# Altium schematic sheet 03_Power_a_Connector.SchDoc(03_Power_a_Connector)
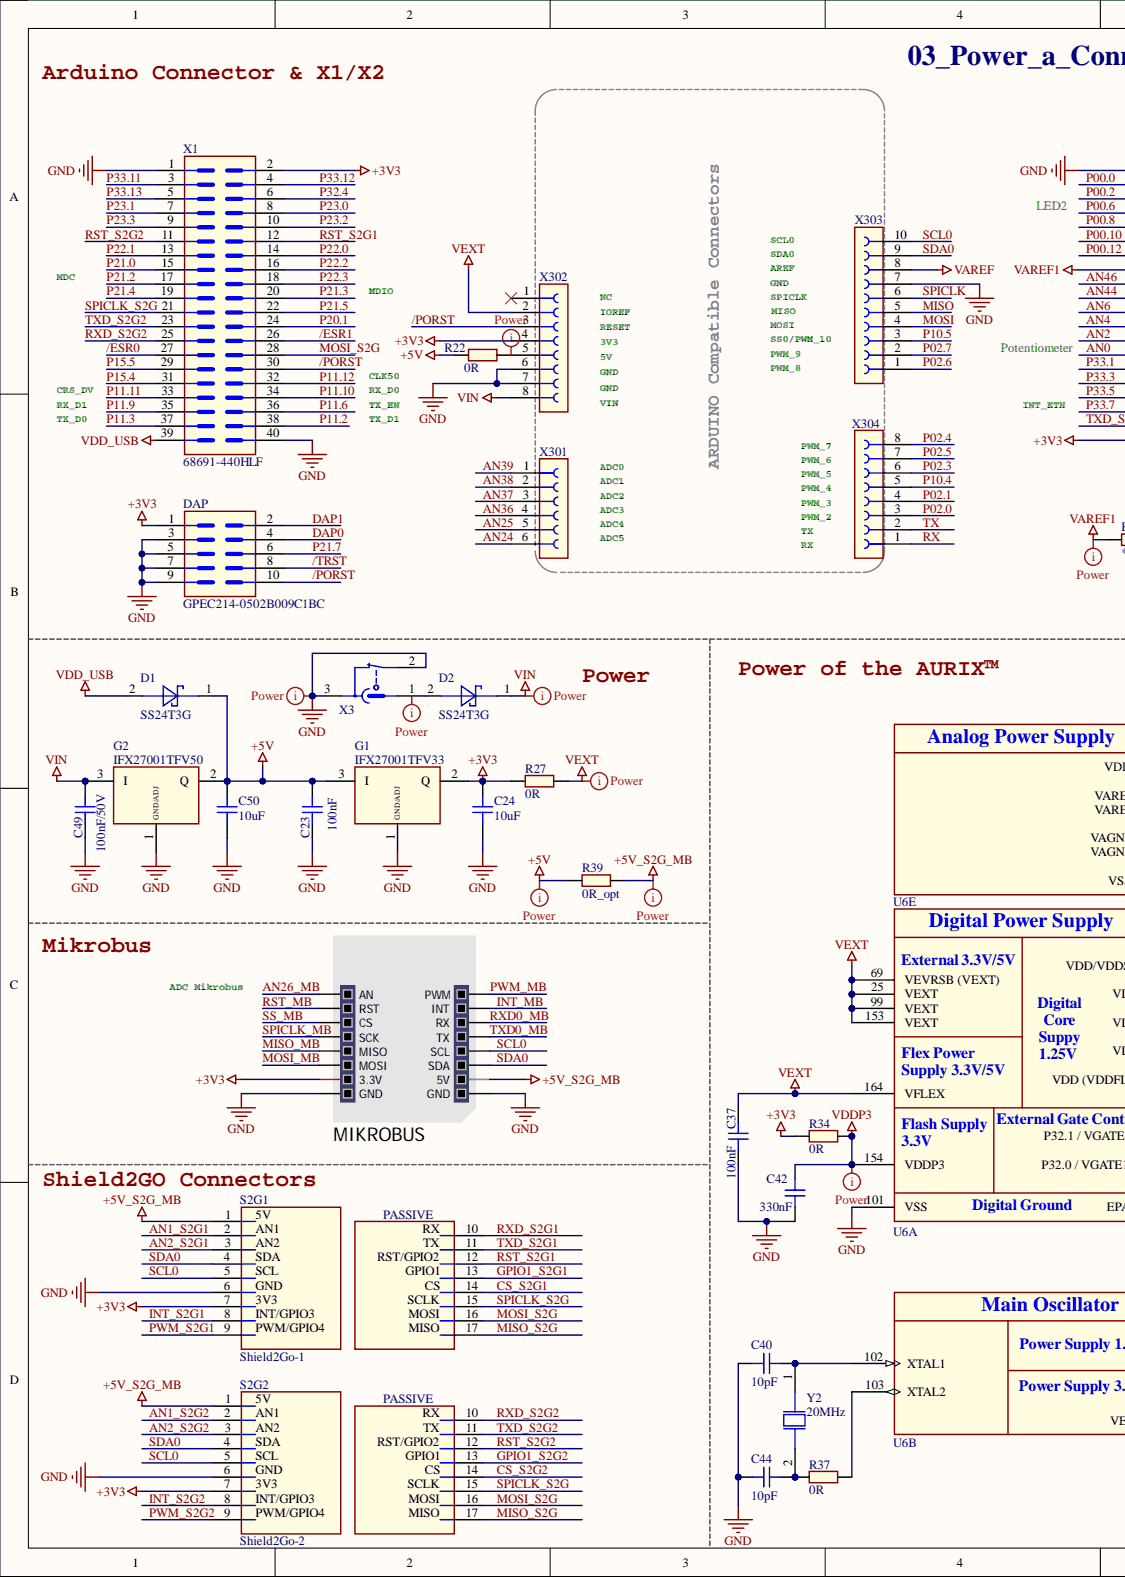
[diagram p0: 03_Power_a_Connector.SchDoc, left]
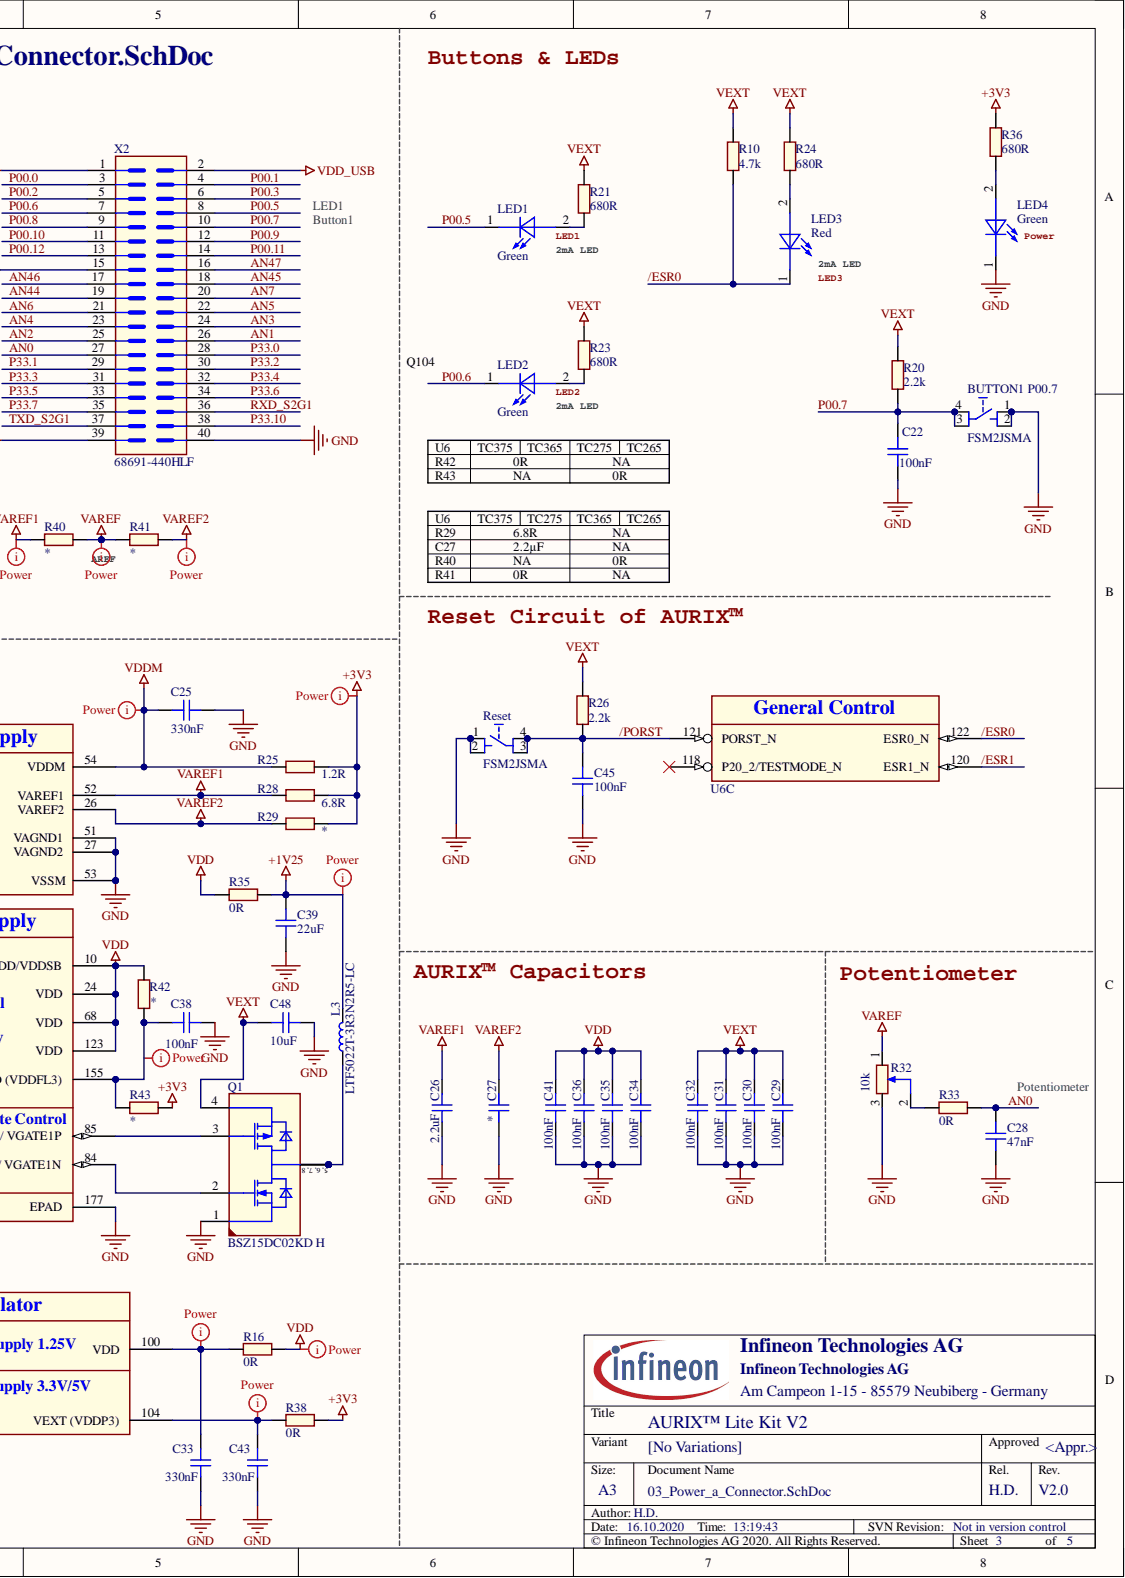
[diagram p1: 03_Power_a_Connector.SchDoc, right]

## Parts
BUTTON1_P00.7  FSM2JSMA  [FSM2JSMA]
C22  100nF  [CAPC1005X55N-1]
C23  100nF  [CAPC1005X55N-1]
C24  10uF  [CAPC1005X70N]
C25  330nF  [CAPC1005X55N-1]
C26  2.2uF  [CAPC1608X90N]
C27  (no value)  [CAPC1608X90N]
C28  47nF  [CAPC1608X90N-4]
C29  100nF  [CAPC1005X55N-1]
C30  100nF  [CAPC1005X55N-1]
C31  100nF  [CAPC1005X55N-1]
C32  100nF  [CAPC1005X55N-1]
C33  330nF  [CAPC1005X55N-1]
C34  100nF  [CAPC1005X55N-1]
C35  100nF  [CAPC1005X55N-1]
C36  100nF  [CAPC1005X55N-1]
C37  100nF  [CAPC1005X55N-1]
C38  100nF  [CAPC1005X55N-1]
C39  22uF  [CAPC3225X280N-3]
C40  10pF  [CAPC1005X55N-0]
C41  100nF  [CAPC1005X55N-1]
C42  330nF  [CAPC1005X55N-1]
C43  330nF  [CAPC1005X55N-1]
C44  10pF  [CAPC1005X55N-0]
C45  100nF  [CAPC1005X55N-1]
C48  10uF  [CAPC3225X280N-5]
C49  100nF/50V  [CAPC1608X90N-4]
C50  10uF  [CAPC1005X70N]
D1  SS24T3G  [DIOM5436X247N]
D2  SS24T3G  [DIOM5436X247N]
DAP  GPEC214-0502B009C1BC  [CON-M-SMD-GPEC214-0502B009C1BC]
G1  IFX27001TFV33  [INF-PG-TO252-3-11_N]
G2  IFX27001TFV50  [INF-PG-TO252-3-11_N]
L3  LTF5022T-3R3N2R5-LC  [IND-LTF5022T]
LED1  Green  Type: LED  [LED-SMD-LG L29K-XXXX-24]
LED2  Green  Type: LED  [LED-SMD-LG L29K-XXXX-24]
LED3  Red  Type: LED  [LEDSC160X80X80-2N]
LED4  Green  [LED-SMD-LG L29K-XXXX-24]
MIKROBUS  MIKROBUS HOST CONN.  [MIKROBUS HOST CONN.]  (value not drawn)
Q1  BSZ15DC02KD H  [INF-PG-TSDSON-8-31]
R10  4.7k  [RESC1005X40N-1]
R16  0R  [RESC1609X50N]
R20  2.2k  [RESC1005X40N]
R21  680R  [RESC1005X40N]
R22  0R  [RESC1609X50N]
R23  680R  [RESC1005X40N]
R24  680R  [RESC1005X40N]
R25  1.2R  [RESC1609X50N]
R26  2.2k  [RESC1005X40N]
R27  0R  [RESC1609X50N]
R28  6.8R  [RESC1609X50N]
R29  (no value)  [RESC1609X50N]
R32  10k  [POT_23A]
R33  0R  [RESC1609X50N]
R34  0R  [RESC1609X50N]
R35  0R  [RESC1609X50N]
R36  680R  [RESC1005X40N]
R37  0R  [RESC1609X50N]
R38  0R  [RESC1609X50N]
R39  0R_opt  [RESC1609X50N]
R40  (no value)  [RESC1609X50N]
R41  (no value)  [RESC1609X50N]
R42  (no value)  [RESC1609X50N]
R43  (no value)  [RESC1609X50N]
Reset  FSM2JSMA  [FSM2JSMA]
S2G1  Shield2Go-1  [Shield2Go_S2G_1P]  (no BOM)
S2G2  Shield2Go-2  [Shield2Go_S2G_1P]  (no BOM)
U6  TC375  [QFP50P2600X2600X160-177N]  (value not drawn)  (parts A,B,C,E)
X1  68691-440HLF  [CON-M-THT-68691-440HLF]
X2  68691-440HLF  [CON-M-THT-68691-440HLF]
X3  con_pwr13_ncc32_3p-A  [CON-THT-JACK-FC681465P]  (value not drawn)
X301  Socket 6  [CON-F-THT-SSQ-106-03-G-S]  (value not drawn)
X302  Socket 8  [CON-F-THT-PPTC081LFBN-RC]  (value not drawn)
X303  PPTC101LFBN-RC  [CON-F-THT-SSQ-110-03-F-S]  (value not drawn)
X304  Socket 8  [CON-F-THT-PPTC081LFBN-RC]  (value not drawn)
Y2  20MHz  [XTAL-SMD-NX8045GB]

## Nets
+1V25: C39.2 L3.2 R35.1
+3V3: C24.2 DAP.1 G1.2 MIKROBUS.7(3.3V) R25.2 R27.1 R28.2 R29.2 R34.2 R36.2 R38.2 R43.2 S2G1.7 S2G2.7(3V3) X1.2 X2.39 X302.4
+5V: C23.1 C50.2 D1.1 G1.3 G2.2 R22.1 R39.1
+5V_S2G_MB: MIKROBUS.10(5V) R39.2 S2G1.1 S2G2.1(5V)
GND: BUTTON1_P00.7.1 BUTTON1_P00.7.2 C22.1 C23.2 C24.1 C25.1 C26.1 C27.1 C28.1 C29.1 C30.1 C31.1 C32.1 C33.1 C34.1 C35.1 C36.2 C37.2 C38.2 C39.1 C40.1 C41.2 C42.1 C43.1 C44.1 C45.1 C48.2 C49.2 C50.1 DAP.3 DAP.5 DAP.7 DAP.9 G1.1 G2.1 LED4.1 MIKROBUS.8(GND) MIKROBUS.9(GND) Q1.1 R32.3 Reset.1 Reset.2 S2G1.6 S2G2.6(GND) U6.27(VAGND2) U6.51(VAGND1) U6.53(VSSM) U6.101(VSS) U6.177(EPAD) X1.1 X1.40 X2.1 X2.40 X3.2 X3.3 X302.6 X302.7 X303.7
VAREF: R32.1 R40.1 R41.1 X303.8
VAREF1: C26.2 R28.1 R40.2 U6.52(VAREF1) X2.15
VAREF2: C27.2 R29.1 R41.2 U6.26(VAREF2)
VDD: C34.2 C35.2 C36.1 C41.1 R16.2 R35.2 R42.2 U6.10(VDD/VDDSB) U6.24(VDD) U6.68(VDD) U6.123(VDD)
VDD_USB: D1.2 X1.39 X2.2
VDDM: C25.2 R25.1 U6.54(VDDM)
VDDP3: C42.2 R34.1 U6.154(VDDP3)
VEXT: C29.2 C30.2 C31.2 C32.2 C37.1 C48.1 Q1.4 R10.2 R20.2 R21.2 R23.2 R24.1 R26.2 R27.2 U6.25(VEXT) U6.69(VEVRSB_(VEXT)) U6.99(VEXT) U6.153(VEXT) U6.164(VFLEX) X302.2
VIN: C49.1 D2.1 G2.3 X302.8
/ESR0: LED3.1 R10.1 U6.122(ESR0_N) X1.27
/ESR1: U6.120(ESR1_N) X1.26
/PORST: C45.2 DAP.10 R26.1 Reset.3 Reset.4 U6.121(PORST_N) X1.30 X302.3
/TRST: DAP.8
AN0: C28.2 R33.2 X2.27
AN1: X2.26
AN1_S2G1: S2G1.2
AN1_S2G2: S2G2.2(AN1)
AN2: X2.25
AN2_S2G1: S2G1.3(AN2)
AN2_S2G2: S2G2.3
AN3: X2.24
AN4: X2.23
AN5: X2.22
AN6: X2.21
AN7: X2.20
AN24: X301.6
AN25: X301.5
AN26_MB: MIKROBUS.1(AN)
AN36: X301.4
AN37: X301.3
AN38: X301.2
AN39: X301.1
AN44: X2.19
AN45: X2.18
AN46: X2.17
AN47: X2.16
CS_S2G1: S2G1.14
CS_S2G2: S2G2.14(CS)
DAP0: DAP.4
DAP1: DAP.2
GPIO1_S2G1: S2G1.13(GPIO1)
GPIO1_S2G2: S2G2.13
INT_MB: MIKROBUS.15(INT)
INT_S2G1: S2G1.8
INT_S2G2: S2G2.8
MISO: X303.5
MISO_MB: MIKROBUS.5(MISO)
MISO_S2G: S2G1.17(MISO) S2G2.17
MOSI: X303.4
MOSI_MB: MIKROBUS.6(MOSI)
MOSI_S2G: S2G1.16 S2G2.16 X1.28
P00.0: X2.3
P00.1: X2.4 X2.11  [also labelled P00.10]
P00.2: X2.5
P00.3: X2.6
P00.5: LED1.1 X2.8
P00.6: LED2.1 X2.7
P00.7: BUTTON1_P00.7.3 BUTTON1_P00.7.4 C22.2 R20.1 X2.10
P00.8: X2.9
P00.9: X2.12
P00.11: X2.14
P00.12: X2.13
P02.0: X304.3
P02.1: X304.4
P02.3: X304.6
P02.4: X304.8
P02.5: X304.7
P02.6: X303.1
P02.7: X303.2
P10.4: X304.5
P10.5: X303.3
P11.2: X1.38
P11.3: X1.37
P11.6: X1.36
P11.9: X1.35
P11.10: X1.34
P11.11: X1.33
P11.12: X1.32
P15.4: X1.31
P15.5: X1.29
P20.1: X1.24
P21.0: X1.15
P21.2: X1.17
P21.3: X1.20
P21.4: X1.19
P21.5: X1.22
P21.7: DAP.6
P22.0: X1.14
P22.1: X1.13
P22.2: X1.16
P22.3: X1.18
P23.0: X1.8
P23.1: X1.7
P23.2: X1.10
P23.3: X1.9
P32.4: X1.6
P33.0: X2.28
P33.1: X2.29 X2.38  [also labelled P33.10]
P33.2: X2.30
P33.3: X2.31
P33.4: X2.32
P33.5: X2.33
P33.6: X2.34
P33.7: X2.35
P33.11: X1.3
P33.12: X1.4
P33.13: X1.5
PWM_MB: MIKROBUS.16(PWM)
PWM_S2G1: S2G1.9(PWM/GPIO4)
PWM_S2G2: S2G2.9
RST_MB: MIKROBUS.2(RST)
RST_S2G1: S2G1.12(RST/GPIO2) X1.12
RST_S2G2: S2G2.12 X1.11
RX: X304.1
RXD0_MB: MIKROBUS.14(RX)
RXD_S2G1: S2G1.10 X2.36
RXD_S2G2: S2G2.10(RX) X1.25
SCL0: MIKROBUS.12(SCL) S2G1.5(SCL) S2G2.5 X303.10
SDA0: MIKROBUS.11(SDA) S2G1.4(SDA) S2G2.4 X303.9
SPICLK: X303.6
SPICLK_MB: MIKROBUS.4(SCK)
SPICLK_S2G: S2G1.15 S2G2.15(SCLK) X1.21
SS_MB: MIKROBUS.3(CS)
TX: X304.2
TXD0_MB: MIKROBUS.13(TX)
TXD_S2G1: S2G1.11(TX) X2.37
TXD_S2G2: S2G2.11 X1.23
NetC33_2: C33.2 R16.1 U6.100(VDD)
NetC38_1: C38.1 R42.1 R43.1 U6.155(VDD_(VDDFL3))
NetC40_2: C40.2 U6.102(XTAL1) Y2.1
NetC43_2: C43.2 R38.1 U6.104(VEXT_(VDDP3))
NetC44_2: C44.2 R37.1 Y2.2
NetD2_2: D2.2 X3.1
NetL3_1: L3.1 Q1.5 Q1.6 Q1.7 Q1.8
NetLED1_2: LED1.2 R21.1
NetLED2_2: LED2.2 R23.1
NetLED3_2: LED3.2 R24.2
NetLED4_2: LED4.2 R36.1
NetQ1_2: Q1.2 U6.84(P32.0_/_VGATE1N)
NetQ1_3: Q1.3 U6.85(P32.1_/_VGATE1P)
NetR22_2: R22.2 X302.5
NetR32_2: R32.2 R33.1
NetR37_2: R37.2 U6.103(XTAL2)

Not connected: U6 118(P20_2/TESTMODE_N); X302 1

## Library data of the parts
BUTTON1_P00.7 Reset = 376475: Micro Miniature Pushbutton Switch; Legacy PartNumber: SW0000003; Manufacturer: Tyco Electronics; Manufacturer Component Status: Active; Automotive Qualified: No; Legacy DeviceID: TEC_FSM2JSMA; Second Source allowed: Yes; Style: Not Applicable; Version Number: V01; Voltage DC (max): na; Article Number: 376475; Component Kind: Standard; ComponentLink1Description: Vendor WebSite; ComponentLink1URL: http://www.tycoelectronics.com/; ComponentLink2Description: Datasheet; ComponentLink2URL: http://webnetprod.muc.infineon.com/pcbportal_prod/WorkFlows/AltiumComponent/StandardDocumentation?Versionid=376476; Manufacturer Order Number: FSM2JSMA; Package Description: Switch,Push button ,4.5mm Pitch,Vertical, 6mm L X 6mm W X 5.4mm H body; Part Type: Electro Mechanic; PLMStatus: Active; RoHS Compliant: Y; Supplier 1: Farnell; Supplier 2: DigiKey; Supplier 3: Mouser; Supplier Part Number 1: 3801287; Supplier Part Number 3: 506-FSM2JSMA; Temperature max: 85; Temperature min: -35; Temperature Range: Military; Value: FSM2JSMA; Library Name: AURIX_Lite_Kit_V2.0.SCHLIB
C22 C23 C29-C32 C34-C38 C41 C45 = 308680: Multilayer Ceramic Chip Capacitor; Manufacturer: TDK Corporation; Manufacturer Component Status: Active; Manufacturer Order Number: CGA2B1X7R1C104K050BC; Multiple Parts: No; Alternate View: Yes; Automotive Qualified: AEC Q200; Combined Parameters: TC:X7R (EIA);Tol.:-10%/+10%;Vdc:16V; Legacy DeviceID: CAP01010669; Package Imperial: 0402; Package Metric: 1005; QuickPick: 100nF / 16V / 10% / X7R (EIA) / 0402 (1005); Style: Single; Symbol Type: Capacitor; Single; Standard; 2 Pins; Tolerance Neg: 10%; Type: Standard; Version Number: V01; Voltage DC (max): 16V; Article Number: 308680; Component Kind: Standard; ComponentLink1Description: Vendor WebSite; ComponentLink1URL: http://www.tdk.com; ComponentLink2Description: Datasheet; ComponentLink2URL: http://webnetprod.muc.infineon.com/PCBPortal_Prod/WorkFlows/AltiumComponent/StandardDocumentation?Versionid=308681; Legacy PartNumber: CAP01010669; Package Description: Capacitor,non-polarized,Chip;1.00mm L X 0.50mm W X 0.55mm H; PackageReference: 0402 (1005); Part Type: Capacitor; PLMStatus: Active; ROHS Compliant: Y; Second Source allowed: Yes; Supplier 1: Farnell; Supplier 2: DigiKey; Supplier 3: Mouser; Supplier Part Number 1: 2458205; Supplier Part Number 2: 445-5613-2-ND; Supplier Part Number 3: 810-CGA2B1X7R1C104K; Technology: Ceramic; Temperature max: 125; Temperature min: -55; Temperature Range: Military; Tolerance Pos: 10%; Value: 100nF; Library Name: AURIX_Lite_Kit_V2.0.SCHLIB
C24 C50 = 310655: Multi-layer Ceramic Capacitor; Manufacturer: Samsung; Manufacturer Component Status: Active; Manufacturer Order Number: CL05A106MP5NUNC; Alternate View: Yes; Automotive Qualified: No; Combined Parameters: TC:X5R (EIA);Tol.:-20%/+20%;Vdc:10V; Legacy DeviceID: CAP01010925; Package Imperial: 0402; Package Metric: 1005; QuickPick: 10uF / 10V / 20% / X5R (EIA) / 0402; Style: Single; Symbol Type: Capacitor; Single; Standard; 2 Pins; Tolerance Neg: 20%; Type: Standard; Version Number: V01; Voltage DC (max): 10V; Article Number: 310655; Component Kind: Standard; ComponentLink1Description: Vendor WebSite; ComponentLink1URL: http://www.samsungsem.co.kr/; ComponentLink2Description: Datasheet; ComponentLink2URL: http://webnetprod.muc.infineon.com/PCBPortal_Prod/WorkFlows/AltiumComponent/StandardDocumentation?Versionid=310656; Legacy PartNumber: CAP01010925; Multiple Parts: No; Package Description: Capacitor,non-polarized,Chip;1.00mm L X 0.50mm W X 0.70mm H; PackageReference: 0402; Part Type: Capacitor; PLMStatus: Active; ROHS Compliant: Y; Second Source allowed: Yes; Supplier 1: Farnell; Supplier 2: DigiKey; Supplier 3: Mouser; Supplier Part Number 2: 1276-1450-2-ND; Supplier Part Number 3: Not Assigned; Technology: Ceramic; Temperature max: 85; Temperature min: -55; Temperature Range: Military; Tolerance Pos: 20%; Value: 10uF; Library Name: AURIX_Lite_Kit_V2.0.SCHLIB
C25 C33 C42 C43 = 310325: Multilayer Ceramic Chip Capacitor; Manufacturer: TDK Corporation; Manufacturer Component Status: Active; Manufacturer Order Number: CGA2B1X7S1C334K050BC; Multiple Parts: No; Alternate View: Yes; Automotive Qualified: AEC Q200; Combined Parameters: TC:X7S (EIA);Tol.:-10%/+10%;Vdc:16V; Legacy DeviceID: CAP01010879; Package Imperial: 0402; Package Metric: 1005; QuickPick: 330nF / 16V / 10% / X7S (EIA) / 0402 (1005); Style: Single; Symbol Type: Capacitor; Single; Standard; 2 Pins; Tolerance Neg: 10%; Type: Standard; Version Number: V01; Voltage DC (max): 16V; Article Number: 310325; Component Kind: Standard; ComponentLink1Description: Vendor WebSite; ComponentLink1URL: http://www.tdk.com; ComponentLink2Description: Datasheet; ComponentLink2URL: http://webnetprod.muc.infineon.com/PCBPortal_Prod/WorkFlows/AltiumComponent/StandardDocumentation?Versionid=310326; Legacy PartNumber: CAP01010879; Package Description: Capacitor,non-polarized,Chip;1.00mm L X 0.50mm W X 0.55mm H; PackageReference: 0402 (1005); Part Type: Capacitor; PLMStatus: Active; ROHS Compliant: N; Second Source allowed: Yes; Supplier 1: Farnell; Supplier 2: DigiKey; Supplier 3: Mouser; Supplier Part Number 2: CGA2B1X7S1C334K050BC-ND; Supplier Part Number 3: 810-CGA2B1X7S1C334KC; Technology: Ceramic; Temperature max: 125; Temperature min: -55; Temperature Range: Military; Tolerance Pos: 10%; Value: 330nF; Library Name: AURIX_Lite_Kit_V2.0.SCHLIB
C26 = 272270: Chip Monolithic Ceramic Capacitor; Manufacturer: MuRata; Manufacturer Component Status: Active; Manufacturer Order Number: GRM188R61E225KA12; Alternate View: Yes; Automotive Qualified: No; Combined Parameters: TC:X5R (EIA);Tol.:-10%/+10%;Vdc:25V; Legacy DeviceID: CAP01003461; Package Imperial: 0603; Package Metric: 1608; QuickPick: 2.2uF / 25V / 10% / X5R (EIA) / CAPC1608X90N; Style: Single; Symbol Type: Capacitor; Single; Standard; 2 Pins; Tolerance Neg: 10%; Type: Standard; Version Number: V01; Voltage DC (max): 25V; Application: Standard; Article Number: 272270; Component Kind: Standard; ComponentLink1Description: Vendor WebSite; ComponentLink1URL: http://www.murata.com/; ComponentLink2Description: Datasheet; ComponentLink2URL: http://webnetprod.muc.infineon.com/PCBPortal_Prod/WorkFlows/AltiumComponent/StandardDocumentation?Versionid=272271; Legacy PartNumber: CAP01003461; Multiple Parts: No; Package Description: Capacitor,non-polarized,Chip;1.60mm L X 0.80mm W X 0.90mm H; PackageReference: CAPC1608X90N; Part Type: Capacitor; PLMStatus: Active; ROHS Compliant: Y; Second Source allowed: Yes; Supplier 1: Farnell; Supplier 2: DigiKey; Supplier 3: Mouser; Technology: Ceramic; Temperature max: 85; Temperature min: -55; Temperature Range: Military; Tolerance Pos: 10%; Value: 2.2uF; Library Name: AURIX_Lite_Kit_V2.0.SCHLIB
C27 = 272270: Chip Monolithic Ceramic Capacitor; Manufacturer: MuRata; Manufacturer Component Status: Active; Manufacturer Order Number: GRM188R61E225KA12; Alternate View: Yes; Automotive Qualified: No; Combined Parameters: TC:X5R (EIA);Tol.:-10%/+10%;Vdc:25V; Legacy DeviceID: CAP01003461; Package Imperial: 0603; Package Metric: 1608; QuickPick: 2.2uF / 25V / 10% / X5R (EIA) / CAPC1608X90N; Style: Single; Symbol Type: Capacitor; Single; Standard; 2 Pins; Tolerance Neg: 10%; Type: Standard; Value: 2.2uF; Version Number: V01; Voltage DC (max): 25V; Application: Standard; Article Number: 272270; Component Kind: Standard; ComponentLink1Description: Vendor WebSite; ComponentLink1URL: http://www.murata.com/; ComponentLink2Description: Datasheet; ComponentLink2URL: http://webnetprod.muc.infineon.com/PCBPortal_Prod/WorkFlows/AltiumComponent/StandardDocumentation?Versionid=272271; Legacy PartNumber: CAP01003461; Multiple Parts: No; Package Description: Capacitor,non-polarized,Chip;1.60mm L X 0.80mm W X 0.90mm H; PackageReference: CAPC1608X90N; Part Type: Capacitor; PLMStatus: Active; ROHS Compliant: Y; Second Source allowed: Yes; Supplier 1: Farnell; Supplier 2: DigiKey; Supplier 3: Mouser; Technology: Ceramic; Temperature max: 85; Temperature min: -55; Temperature Range: Military; Tolerance Pos: 10%; Library Name: AURIX_Lite_Kit_V2.0.SCHLIB
C28 = 309989: Multilayer Ceramic Chip Capacitor; Manufacturer: TDK Corporation; Manufacturer Component Status: Active; Manufacturer Order Number: C1608X8R1H473K080AA; Alternate View: Yes; Automotive Qualified: No; Combined Parameters: TC:X8R (EIA);Tol.:-10%/+10%;Vdc:50V; Legacy DeviceID: CAP01010836; Package Imperial: 0603; Package Metric: 1608; QuickPick: 47nF / 50V / 10% / X8R (EIA) / 0603 (1608); Style: Single; Symbol Type: Capacitor; Single; Standard; 2 Pins; Tolerance Neg: 10%; Type: Standard; Version Number: V01; Voltage DC (max): 50V; Article Number: 309989; Component Kind: Standard; ComponentLink1Description: Vendor WebSite; ComponentLink1URL: http://www.tdk.com; ComponentLink2Description: Datasheet; ComponentLink2URL: http://webnetprod.muc.infineon.com/PCBPortal_Prod/WorkFlows/AltiumComponent/StandardDocumentation?Versionid=309990; Legacy PartNumber: CAP01010836; Multiple Parts: No; Package Description: Capacitor,non-polarized,Chip;1.60mm L X 0.80mm W X 0.90mm H; PackageReference: 0603 (1608); Part Type: Capacitor; PLMStatus: Active; ROHS Compliant: Y; Second Source allowed: Yes; Supplier 1: Farnell; Supplier 2: DigiKey; Supplier 3: Mouser; Supplier Part Number 1: 2354114; Supplier Part Number 2: 445-2506-1-ND; Supplier Part Number 3: 810-C1608X8R1H473K; Technology: Ceramic; Temperature max: 150; Temperature min: -55; Temperature Range: Military; Tolerance Pos: 10%; Value: 47nF; Library Name: AURIX_Lite_Kit_V2.0.SCHLIB
C39 = 308865: Temperature Stable MLCC Ceramic Capacitor; Manufacturer: Kemet; Manufacturer Component Status: Active; Manufacturer Order Number: C1210C226K4PACTU; Alternate View: Yes; Automotive Qualified: No; Combined Parameters: TC:X5R (EIA);Tol.:-10%/+10%;Vdc:16V; Legacy DeviceID: CAP01010692; Package Imperial: 1210; Package Metric: 3225; QuickPick: 22uF / 16V / 10% / X5R (EIA) / 1210; Style: Single; Symbol Type: Capacitor; Single; Standard; 2 Pins; Tolerance Neg: 10%; Type: Standard; Version Number: V01; Voltage DC (max): 16V; Article Number: 308865; Component Kind: Standard; ComponentLink1Description: Vendor WebSite; ComponentLink1URL: http://www.kemet.com; ComponentLink2Description: Datasheet; ComponentLink2URL: http://webnetprod.muc.infineon.com/PCBPortal_Prod/WorkFlows/AltiumComponent/StandardDocumentation?Versionid=308866; Legacy PartNumber: CAP01010692; Multiple Parts: No; Package Description: Capacitor,non-polarized,Chip;3.20mm L X 2.50mm W X 2.80mm H; PackageReference: 1210; Part Type: Capacitor; PLMStatus: Active; ROHS Compliant: Y; Second Source allowed: Yes; Supplier 1: Farnell; Supplier 2: DigiKey; Supplier 3: Mouser; Supplier Part Number 1: 2392271; Supplier Part Number 2: 399-5092-2-ND; Supplier Part Number 3: 80-C1210C226K4P; Technology: Ceramic; Temperature max: 85; Temperature min: -55; Temperature Range: Military; Tolerance Pos: 10%; Value: 22uF; Library Name: AURIX_Lite_Kit_V2.0.SCHLIB
C40 C44 = 287201: Chip Monolithic Ceramic Capacitor; Manufacturer: MuRata; Manufacturer Component Status: Active; Manufacturer Order Number: GCM1555C1H100FA16; Multiple Parts: No; Alternate View: Yes; Automotive Qualified: AEC Q200; Combined Parameters: TC:C0G (EIA) / NP0;Tol.:-1%/+1%;Vdc:50V; Legacy DeviceID: CAP01006513; Package Imperial: 0402; Package Metric: 1005; QuickPick: 10pF / 50V / 1% / C0G (EIA) / NP0 / CAPC1005X55N-0; Style: Single; Symbol Type: Capacitor; Single; Standard; 2 Pins; Tolerance Neg: 1%; Type: Standard; Version Number: V01; Voltage DC (max): 50V; Application: Standard; Article Number: 287201; Component Kind: Standard; ComponentLink1Description: Vendor WebSite; ComponentLink1URL: http://www.murata.com/; ComponentLink2Description: Datasheet; ComponentLink2URL: http://webnetprod.muc.infineon.com/PCBPortal_Prod/WorkFlows/AltiumComponent/StandardDocumentation?Versionid=287202; Legacy PartNumber: CAP01006513; Package Description: Capacitor,non-polarized,Chip;1.00mm L X 0.50mm W X 0.55mm H; PackageReference: CAPC1005X55N-0; Part Type: Capacitor; PLMStatus: Active; ROHS Compliant: Y; Second Source allowed: Yes; Supplier 1: Farnell; Supplier 2: DigiKey; Supplier 3: Mouser; Technology: Ceramic; Temperature max: 125; Temperature min: -55; Temperature Range: Military; Tolerance Pos: 1%; Value: 10pF; Library Name: AURIX_Lite_Kit_V2.0.SCHLIB
C48 = 597437: Multilayer Ceramic Chip Capacitor; Manufacturer: Kemet; Manufacturer Order Number: C1210C106K5RACTU; Alternate View: Yes; Automotive Qualified: No; Combined Parameters: TC:X7R (EIA);Tol.:-10%/+10%;Vdc:50V; PLMStatus: Active; QuickPick: 10uF / 50V / 10% / X7R (EIA) / 1210 (3225); Style: Single; Symbol Type: Capacitor; Single; Standard; 2 Pins; Tolerance Neg: 10%; Type: Standard; Version Number: V01; Voltage DC (max): 50V; Application: Standard; Article Number: 597437; Component Kind: Standard; ComponentLink1Description: Vendor WebSite; ComponentLink1URL: http://www.kemet.com; ComponentLink2Description: Datasheet; ComponentLink2URL: http://webnetprod.muc.infineon.com/PCBPortal_Prod/WorkFlows/AltiumComponent/StandardDocumentation?Versionid=597438; Multiple Parts: No; Package Description: Capacitor,non-polarized,Chip;3.20mm L X 2.50mm W X 2.80mm H; PackageReference: 1210 (3225); Part Type: Capacitor; ROHS Compliant: Y; Second Source allowed: Yes; Supplier 1: Farnell; Supplier 2: DigiKey; Supplier 3: Mouser; Supplier Part Number 1: 2449143; Supplier Part Number 2: 399-11629-1-ND; Supplier Part Number 3: 80-C1210C106K5R; Technology: Ceramic; Temperature max: 125; Temperature min: -55; Temperature Range: Military; Tolerance Pos: 10%; Value: 10uF; Library Name: AURIX_Lite_Kit_V2.0.SCHLIB
C49 = 497792: Multilayer Ceramic Chip Capacitors, Automotive grade, 100 nF, 20%, 100V, 0603; Manufacturer: TDK Corporation; Manufacturer Order Number: CGA3E3X7S2A104M080AB; Multiple Parts: No; Alternate View: Yes; Automotive Qualified: AEC Q200; Combined Parameters: TC:X7S (EIA);Tol.:-20%/+20%;Vdc:100V; Package Imperial: 0603; Package Metric: 1608; PLMStatus: Active; QuickPick: 100nF / 100V / 20% / X7S (EIA) / 0603; Style: Single; Symbol Type: Capacitor; Single; Standard; 2 Pins; Type: Standard; Value: 100nF; Version Number: V01; Application: Standard; Article Number: 497792; Component Kind: Standard; ComponentLink1Description: Vendor WebSite; ComponentLink1URL: http://www.tdk.com; ComponentLink2Description: Datasheet; ComponentLink2URL: http://webnetprod.muc.infineon.com/PCBPortal_Prod/WorkFlows/AltiumComponent/StandardDocumentation?Versionid=497793; Package Description: Capacitor,non-polarized,Chip;1.60mm L X 0.80mm W X 0.90mm H; PackageReference: 0603; Part Type: Capacitor; ROHS Compliant: Y; Second Source allowed: Yes; Supplier 1: Farnell; Supplier 2: DigiKey; Supplier 3: Mouser; Supplier Part Number 1: 2803337; Supplier Part Number 2: 445-12576-1-ND; Supplier Part Number 3: 810-CGA3E3X7S2A104AB; Technology: Ceramic; Temperature max: 125; Temperature min: -55; Temperature Range: Military; Tolerance Neg: 20%; Tolerance Pos: 20%; Voltage DC (max): 100V; Library Name: AURIX_Lite_Kit_V2.0.SCHLIB
D1 D2 = 525645: Schottky Power Rectifier; Manufacturer: ON Semiconductor; Manufacturer Order Number: NRVBSS24T3G; Automotive Qualified: Yes; Combined Parameters: Vf:500mV;If:2A;Ur:40V;Ir:400uA;Pmax:-; PLMStatus: Active; QuickPick: Schottky Diode / SMB (CASE 403A-03); Style: Single; Symbol Type: Standard Schottky Diode Symbol, 1 Cathode, 2 Anode; Type: Schottky Diode; Value: NRVBSS24T3G; Version Number: V01; Voltage DC (max): na; Application: Standard; Article Number: 525645; Component Kind: Standard; ComponentLink1Description: Vendor WebSite; ComponentLink1URL: http://onsemi.com/; ComponentLink2Description: Datasheet; ComponentLink2URL: http://webnetprod.muc.infineon.com/PCBPortal_Prod/WorkFlows/AltiumComponent/StandardDocumentation?Versionid=525646; Package Description: Diode,Molded Body;5.405mm L X 3.625mm W X 2.47mm H; PackageReference: SMB (CASE 403A-03); Part Type: Diode; ROHS Compliant: Y; Second Source allowed: Yes; Supplier 1: Farnell; Supplier 2: DigiKey; Supplier 3: Mouser; Supplier Part Number 1: 2844741; Supplier Part Number 2: NRVBSS24T3GOSCT-ND; Supplier Part Number 3: 863-NRVBSS24T3G; Temperature max: 150; Temperature min: -55; Temperature Range: Military; Library Name: AURIX_Lite_Kit_V2.0.SCHLIB
DAP = 591835: 1.27 Pin Header Dual Rows Smt Type,  Brass, 1 Amp, 1000 Mohm min, 300vAC; Manufacturer: Greenconn Corporation; Manufacturer Component Status: Active; Automotive Qualified: No; Combined Parameters: Board-to-Board Header (male);5x2;Mounting:SMT;Orient:0Â° (vertical);Shielded:-; Legacy DeviceID: GC_GPEC214-0502B009C1BC; QuickPick: 0Â° (vertical) / Board-to-Board; Second Source allowed: Yes; Style: Strip; Version Number: V01; Voltage DC (max): na; Article Number: 591835; Component Kind: Standard; ComponentLink1Description: Vendor WebSite; ComponentLink1URL: http://www.greenconn.com/; ComponentLink2Description: Datasheet; ComponentLink2URL: http://webnetprod.muc.infineon.com/PCBPortal_Prod/WorkFlows/AltiumComponent/StandardDocumentation?Versionid=591836; Manufacturer Order Number: GPEC214-0502B009C1BC; Package Description: Connector, 1.27 mm Pitch; 10 pin, 3.40 mm L X 6.35 mm W X 7.70 mm H body; PackageReference: SMD; Part Type: Connector; PLMStatus: Active; ROHS Compliant: N; Supplier 1: Farnell; Supplier 2: DigiKey; Supplier 3: Mouser; Supplier Part Number 1: 2830427; Temperature max: 105; Temperature min: -40; Temperature Range: Industrial; Type: Board-to-Board; Value: GPEC214-0502B009C1BC; Library Name: AURIX_Lite_Kit_V2.0.SCHLIB
G1 = 420430: Linear Voltage Regulator, 4.7 to 40 V Supply, 3.3 V Output; Legacy PartNumber: IFX01400120; Manufacturer: Infineon Technologies; Manufacturer Component Status: Active; Automotive Qualified: No; Combined Parameters: Linear Not applicable -;Vo:3.30V to 3.30V; Legacy DeviceID: IFX_IFX27001TFV33; QuickPick: Linear / PG-TO252-3-11_N; Second Source allowed: Yes; Style: Not applicable; Symbol type: Linear Voltage Regulator, 4.7 to 40 V Supply, Fixed/Adjustable Output, -40 to 125 degC, PG-TO252-3, Reel, Green; Version Number: V01; Voltage DC (max): na; Article Number: 420430; Component Kind: Standard; ComponentLink1Description: Vendor WebSite; ComponentLink1URL: https://www.infineon.com/; ComponentLink2Description: Datasheet; ComponentLink2URL: http://webnetprod.muc.infineon.com/PCBPortal_Prod/WorkFlows/AltiumComponent/StandardDocumentation?Versionid=420431; Manufacturer Order Number: IFX27001TFV33; Package Description: DPAK, 3-Leads, Body 6.5x6.12mm, Pitch 2.28mm, IPC Medium Density; PackageReference: PG-TO252-3-11_N; Part Type: Power Supply; PLMStatus: Active; ROHS Compliant: Y; Supplier 1: Farnell; Supplier 2: DigiKey; Supplier 3: Mouser; Supplier Part Number 1: 2480520; Supplier Part Number 2: IFX27001TFV33ATMA1TR-ND; Temperature max: 125; Temperature min: -40; Temperature Range: Automotive; Type: Linear; Value: IFX27001TFV33; Library Name: AURIX_Lite_Kit_V2.0.SCHLIB
G2 = 420380: Linear Voltage Regulator, 4.7 to 40 V Supply, 5.0 V Output; Legacy PartNumber: IFX01400113; Manufacturer: Infineon Technologies; Automotive Qualified: No; Combined Parameters: Linear Not applicable -;Vo:5V to 5V; Legacy DeviceID: IFX_IFX27001TFV50; PLMStatus: Active; QuickPick: Linear / PG-TO252-3-11_N; Second Source allowed: Yes; Style: Not applicable; Symbol type: Linear Voltage Regulator, 4.7 to 40 V Supply, Fixed/Adjustable Output, -40 to 125 degC, PG-TO252-3, Reel, Green; Version Number: V01; Voltage DC (max): na; Article Number: 420380; Component Kind: Standard; ComponentLink1Description: Vendor WebSite; ComponentLink1URL: https://www.infineon.com/; ComponentLink2Description: Datasheet; ComponentLink2URL: http://webnetprod.muc.infineon.com/PCBPortal_Prod/WorkFlows/AltiumComponent/StandardDocumentation?Versionid=420381; Manufacturer Order Number: IFX27001TFV50; Package Description: DPAK, 3-Leads, Body 6.5x6.12mm, Pitch 2.28mm, IPC Medium Density; PackageReference: PG-TO252-3-11_N; Part Type: Power Supply; ROHS Compliant: Y; Supplier 1: Farnell; Supplier 2: DigiKey; Supplier 3: Mouser; Supplier Part Number 1: 2215562; Supplier Part Number 2: IFX27001TFV50ATMA1TR-ND; Supplier Part Number 3: 726-IFX27001TFV50ATM; Temperature max: 150; Temperature min: -40; Temperature Range: Automotive; Type: Linear; Value: IFX27001TFV50; Library Name: AURIX_Lite_Kit_V2.0.SCHLIB
L3 = 326302: SMD Power Inductor; Manufacturer: TDK Corporation; Alternate View: Yes; Automotive Qualified: No; Combined Parameters: Tol.:-30%/+30%;Rdc:-;Idc:2.7A;Fres:-; Legacy DeviceID: IND00702711; PLMStatus: Active; QuickPick: 3.3uH / SMD; Symbol Type: Inductor; Single; Standard; 2 Pins; Tolerance Neg: 30%; Value: 3.3uH; Version Number: V01; Voltage DC (max): na; Article Number: 326302; Component Kind: Standard; ComponentLink1Description: Vendor WebSite; ComponentLink1URL: http://www.tdk.com; ComponentLink2Description: Datasheet; ComponentLink2URL: http://webnetprod.muc.infineon.com/PCBPortal_Prod/WorkFlows/AltiumComponent/StandardDocumentation?Versionid=326303; Legacy PartNumber: IND00702711; Manufacturer Order Number: LTF5022T-3R3N2R5-LC; Multiple Parts: No; Package Description: Inductor,Molded Body; 5mm L X 5.2mm W X 2.2mm H; PackageReference: SMD; Part Type: Inductor; Second Source allowed: Yes; Supplier 1: Farnell; Supplier 2: DigiKey; Supplier 3: Mouser; Supplier Part Number 1: 2345125RL; Supplier Part Number 2: 445-6195-2-ND; Supplier Part Number 3: 810-LTF5022T3R3N2R5L; Temperature Range: Military; Tolerance Pos: 30%; Library Name: AURIX_Lite_Kit_V2.0.SCHLIB
LED1 LED2 LED4 = 395008: Surface Mount LED, Green, 570nm; Manufacturer: OSRAM Opto Semiconductors; Manufacturer Component Status: Active; Manufacturer Order Number: LG L29K-G2J1-24; Automotive Qualified: No; Combined Parameters: Vf:2.2V;If:20mA;Ur:12V;Ir:10nA;Pmax:-; Legacy DeviceID: DIO01300294; QuickPick: LED / 0603; Second Source allowed: Yes; Style: Single; Symbol Type: Standard LED Symbol, 1 Cathode, 2 Anode; Version Number: V01; Voltage DC (max): na; Application: Standard; Article Number: 395008; Component Kind: Standard; ComponentLink1Description: Vendor WebSite; ComponentLink1URL: http://www.osram-os.com; ComponentLink2Description: Datasheet; ComponentLink2URL: http://webnetprod.muc.infineon.com/PCBPortal_Prod/WorkFlows/AltiumComponent/StandardDocumentation?Versionid=395009; Legacy PartNumber: DIO01300294; Package Description: LED;2 pin,1.3mm W X 0.80mm L X 0.67mm H body; PackageReference: 0603; Part Type: Diode; PLMStatus: Active; ROHS Compliant: Y; Supplier 1: Farnell; Supplier 2: DigiKey; Supplier 3: Mouser; Supplier Part Number 2: 475-2709-2-ND; Supplier Part Number 3: 720-LGL29KG2J124Z; Temperature max: 100; Temperature min: -40; Temperature Range: Industrial; Value: Green; Library Name: AURIX_Lite_Kit_V2.0.SCHLIB
  LED4: Type: LED
LED3 = 494918: Surface Mount LED, Red, 633nm; Manufacturer: OSRAM Opto Semiconductors; Manufacturer Component Status: Active; Manufacturer Order Number: LSQ976-Z; Automotive Qualified: No; Combined Parameters: Vf:2.5V;If:25mA;Ur:12V;Ir:10uA;Pmax:-; Second Source allowed: Yes; Style: Single; Symbol Type: Standard LED Symbol, 1 Cathode, 2 Anode; Version Number: V01; Voltage DC (max): na; Application: Standard; Article Number: 494918; Component Kind: Standard; ComponentLink1Description: Vendor WebSite; ComponentLink1URL: http://www.osram-os.com; ComponentLink2Description: Datasheet; ComponentLink2URL: http://webnetprod.muc.infineon.com/pcbportal_prod/WorkFlows/AltiumComponent/StandardDocumentation?Versionid=494919; Package Description: LED,Side Concave;2 pin,1.60mm W X 0.80mm L X 0.80mm H; PackageReference: 603; Part Type: Diode; PLMStatus: Active; RoHS Compliant: N; Supplier 1: Farnell; Supplier 2: DigiKey; Supplier 3: Mouser; Supplier Part Number 1: 1226390RL; Temperature max: 85; Temperature min: -30; Temperature Range: Military; Value: Red; Library Name: AURIX_Lite_Kit_V2.0.SCHLIB
MIKROBUS = MIKROBUS HOST CONN._1: mikroBUS Host Socket; Manufacturer Order Number: 2xPPTC081LFBN-RC; Component Kind: Standard; SMD: No; Library Name: AURIX_Lite_Kit_V2.0.SCHLIB
Q1 = 425454: OptiMOS 2+OptiMOS-P Complementary P + N Channel Enhancement 2 Small Signal Transistor; Legacy PartNumber: IFX01400764; Manufacturer: Infineon Technologies; Alternate View: Yes; Automotive Qualified: AEC Q101; Combined Parameters: N-Channel Depletion and P-Channel Depletion;Uds:20V;Id:5.1A;Rds:310mR;P:-; Legacy DeviceID: IFX_BSZ15DC02KD H; PLMStatus: Active; QuickPick: N-Channel Depletion and P-Channel Depletion / 5.1A / 20V / PG-TSDSON-8-31; Style: Dual; Symbol Type: Dual Insulated-Gate Field-Effect Transistor (IGFET), P+N-Channel, Enhancement, Pin 1 Source, 2 Gate, 3 Gate, 4 Source, 5 Drain, 6 Drain, 7 Drain, 8 Drain, 8 Pins; Type: N-Channel Depletion and P-Channel Depletion; Version Number: V01; Voltage DC (max): na; Application: Small Signal; Article Number: 425454; Component Kind: Standard; ComponentLink1Description: Vendor WebSite; ComponentLink1URL: https://www.infineon.com/; ComponentLink2Description: Datasheet; ComponentLink2URL: http://webnetprod.muc.infineon.com/PCBPortal_Prod/WorkFlows/AltiumComponent/StandardDocumentation?Versionid=425455; Manufacturer Order Number: BSZ15DC02KD H; Package Description: SON,0.65mm pitch;8 pin,3.3mm W X 3.3mm L X 1mm H body; PackageReference: PG-TSDSON-8-31; Part Type: Transistor FET; ROHS Compliant: Y; Second Source allowed: Yes; Supplier 1: Farnell; Supplier 2: DigiKey; Supplier 3: Mouser; Supplier Part Number 1: 2726092; Supplier Part Number 2: BSZ15DC02KDHXTMA1TR-ND; Supplier Part Number 3: 726-BSZ15DC02KDHXTMA; Temperature max: 175; Temperature min: -55; Temperature Range: Military; Value: BSZ15DC02KD H; Library Name: AURIX_Lite_Kit_V2.0.SCHLIB
R10 = 327541: General Purpose Chip Resistor; Manufacturer: Yageo; Manufacturer Component Status: Active; Manufacturer Order Number: RC0402FR-074K7L; Alternate View: Yes; Automotive Qualified: No; Combined Parameters: TCR:100ppm/K;Tol.:-1%/+1%;Vdc:50V;Idc:-;P:63mW; Package Imperial: 0402; Package Metric: 1005; QuickPick: 4.7k / 1% / 0.0630 / 0402; Style: Single; Symbol Type: Resistor; Single; Standard; 2 Pins; Tolerance Neg: 1%; Version Number: V01; Voltage DC (max): 50V; Application: Standard; Article Number: 327541; Component Kind: Standard; ComponentLink1Description: Vendor WebSite; ComponentLink1URL: http://www.yageo.com/; ComponentLink2Description: Datasheet; ComponentLink2URL: http://webnetprod.muc.infineon.com/PCBPortal_Prod/WorkFlows/AltiumComponent/StandardDocumentation?Versionid=327542; Multiple Parts: No; Package Description: Resistor,Chip;1.00mm L X 0.50mm W X 0.40mm H; PackageReference: 0402; Part Type: Resistor; PLMStatus: Active; ROHS Compliant: Y; Second Source allowed: Yes; Supplier 1: Farnell; Supplier 2: DigiKey; Supplier 3: Mouser; Supplier Part Number 1: 9239316; Supplier Part Number 2: 311-4.7KLRTR-ND; Supplier Part Number 3: 603-RC0402FR-074K7L; Technology: Thick Film; Temperature max: 155; Temperature min: -55; Temperature Range: Military; Tolerance Pos: 1%; Type: Standard; Value: 4.7k; Library Name: AURIX_Lite_Kit_V2.0.SCHLIB
R16 R22 R27 R33-R35 R37 R38 = 343882: Standard Thick Film Chip Resistor; Manufacturer: Vishay; Manufacturer Order Number: CRCW06030000Z0; Multiple Parts: No; Alternate View: Yes; Automotive Qualified: AEC Q200; Combined Parameters: TCR:-;Tol.:-0R/+0R;Vdc:75V;Idc:2A;P:-; Legacy DeviceID: RES01800800; PLMStatus: Active; QuickPick: 0R / 0R / 0603; Style: Single; Symbol Type: Resistor; Single; Standard; 2 Pins; Version Number: V01; Voltage DC (max): 75V; Article Number: 343882; Component Kind: Standard; ComponentLink1Description: Vendor WebSite; ComponentLink1URL: http://www.vishay.com; ComponentLink2Description: Datasheet; ComponentLink2URL: http://webnetprod.muc.infineon.com/PCBPortal_Prod/WorkFlows/AltiumComponent/StandardDocumentation?Versionid=343883; Legacy PartNumber: RES01800800; PackageReference: 0603; Part Type: Resistor; ROHS Compliant: Y; Second Source allowed: Yes; Supplier 1: Farnell; Supplier 2: DigiKey; Supplier 3: Mouser; Supplier Part Number 2: 541-0.0GCT-ND; Technology: Thick Film; Temperature max: 155; Temperature min: -55; Temperature Range: Military; Tolerance Neg: 0R; Tolerance Pos: 0R; Type: Standard; Value: 0R; Library Name: AURIX_Lite_Kit_V2.0.SCHLIB
R20 R26 = 341777: Standard Thick Film Chip Resistor; Manufacturer: Vishay; Manufacturer Component Status: Active; Manufacturer Order Number: CRCW04022K20FK; Multiple Parts: No; Alternate View: Yes; Automotive Qualified: AEC Q200; Combined Parameters: TCR:100ppm/K;Tol.:-1%/+1%;Vdc:50V;Idc:-;P:63mW; Legacy DeviceID: RES01800379; QuickPick: 2.2k / 1% / 0.0630 / 0402; Style: Single; Symbol Type: Resistor; Single; Standard; 2 Pins; Tolerance Neg: 1%; Version Number: V01; Voltage DC (max): 50V; Article Number: 341777; Component Kind: Standard; ComponentLink1Description: Vendor WebSite; ComponentLink1URL: http://www.vishay.com; ComponentLink2Description: Datasheet; ComponentLink2URL: http://webnetprod.muc.infineon.com/PCBPortal_Prod/WorkFlows/AltiumComponent/StandardDocumentation?Versionid=341778; Legacy PartNumber: RES01800379; PackageReference: 0402; Part Type: Resistor; PLMStatus: Active; ROHS Compliant: Y; Second Source allowed: Yes; Supplier 1: Farnell; Supplier 2: DigiKey; Supplier 3: Mouser; Technology: Thick Film; Temperature max: 155; Temperature min: -55; Temperature Range: Military; Tolerance Pos: 1%; Type: Standard; Value: 2.2k; Library Name: AURIX_Lite_Kit_V2.0.SCHLIB
R21 R23 R24 R36 = 341502: Standard Thick Film Chip Resistor; Manufacturer: Vishay; Manufacturer Order Number: CRCW0402680RFK; Multiple Parts: No; Alternate View: Yes; Automotive Qualified: AEC Q200; Combined Parameters: TCR:100ppm/K;Tol.:-1%/+1%;Vdc:50V;Idc:-;P:63mW; Legacy DeviceID: RES01800324; PLMStatus: Active; QuickPick: 680R / 1% / 0.0630 / 0402; Style: Single; Symbol Type: Resistor; Single; Standard; 2 Pins; Tolerance Neg: 1%; Version Number: V01; Voltage DC (max): 50V; Article Number: 341502; Component Kind: Standard; ComponentLink1Description: Vendor WebSite; ComponentLink1URL: http://www.vishay.com; ComponentLink2Description: Datasheet; ComponentLink2URL: http://webnetprod.muc.infineon.com/PCBPortal_Prod/WorkFlows/AltiumComponent/StandardDocumentation?Versionid=341503; Legacy PartNumber: RES01800324; PackageReference: 0402; Part Type: Resistor; ROHS Compliant: Y; Second Source allowed: Yes; Supplier 1: Farnell; Supplier 2: DigiKey; Supplier 3: Mouser; Technology: Thick Film; Temperature max: 155; Temperature min: -55; Temperature Range: Military; Tolerance Pos: 1%; Type: Standard; Value: 680R; Library Name: AURIX_Lite_Kit_V2.0.SCHLIB
R25 = 343932: Standard Thick Film Chip Resistor; Manufacturer: Vishay; Manufacturer Component Status: Active; Manufacturer Order Number: CRCW06031R20FK; Multiple Parts: No; Alternate View: Yes; Automotive Qualified: AEC Q200; Combined Parameters: TCR:100ppm/K;Tol.:-1%/+1%;Vdc:75V;Idc:-;P:100mW; Legacy DeviceID: RES01800809; QuickPick: 1.2R / 1% / 0.10 / 0603; Style: Single; Symbol Type: Resistor; Single; Standard; 2 Pins; Tolerance Neg: 1%; Version Number: V01; Voltage DC (max): 75V; Article Number: 343932; Component Kind: Standard; ComponentLink1Description: Vendor WebSite; ComponentLink1URL: http://www.vishay.com; ComponentLink2Description: Datasheet; ComponentLink2URL: http://webnetprod.muc.infineon.com/PCBPortal_Prod/WorkFlows/AltiumComponent/StandardDocumentation?Versionid=343933; Legacy PartNumber: RES01800809; PackageReference: 0603; Part Type: Resistor; PLMStatus: Active; ROHS Compliant: Y; Second Source allowed: Yes; Supplier 1: Farnell; Supplier 2: DigiKey; Supplier 3: Mouser; Technology: Thick Film; Temperature max: 155; Temperature min: -55; Temperature Range: Military; Tolerance Pos: 1%; Type: Standard; Value: 1.2R; Library Name: AURIX_Lite_Kit_V2.0.SCHLIB
R28 = 344367: Standard Thick Film Chip Resistor; Manufacturer: Vishay; Manufacturer Component Status: Active; Manufacturer Order Number: CRCW06036R80FK; Multiple Parts: No; Alternate View: Yes; Automotive Qualified: AEC Q200; Combined Parameters: TCR:100ppm/K;Tol.:-1%/+1%;Vdc:75V;Idc:-;P:100mW; Legacy DeviceID: RES01800896; QuickPick: 6.8R / 1% / 0.10 / 0603; Style: Single; Symbol Type: Resistor; Single; Standard; 2 Pins; Tolerance Neg: 1%; Version Number: V01; Voltage DC (max): 75V; Article Number: 344367; Component Kind: Standard; ComponentLink1Description: Vendor WebSite; ComponentLink1URL: http://www.vishay.com; ComponentLink2Description: Datasheet; ComponentLink2URL: http://webnetprod.muc.infineon.com/PCBPortal_Prod/WorkFlows/AltiumComponent/StandardDocumentation?Versionid=344368; Legacy PartNumber: RES01800896; PackageReference: 0603; Part Type: Resistor; PLMStatus: Active; ROHS Compliant: Y; Second Source allowed: Yes; Supplier 1: Farnell; Supplier 2: DigiKey; Supplier 3: Mouser; Technology: Thick Film; Temperature max: 155; Temperature min: -55; Temperature Range: Military; Tolerance Pos: 1%; Type: Standard; Value: 6.8R; Library Name: AURIX_Lite_Kit_V2.0.SCHLIB
R29 = 344367: Standard Thick Film Chip Resistor; Manufacturer: Vishay; Manufacturer Component Status: Active; Manufacturer Order Number: CRCW06036R80FK; Multiple Parts: No; Alternate View: Yes; Automotive Qualified: AEC Q200; Combined Parameters: TCR:100ppm/K;Tol.:-1%/+1%;Vdc:75V;Idc:-;P:100mW; Legacy DeviceID: RES01800896; QuickPick: 6.8R / 1% / 0.10 / 0603; Style: Single; Symbol Type: Resistor; Single; Standard; 2 Pins; Tolerance Neg: 1%; Value: 6.8R; Version Number: V01; Voltage DC (max): 75V; Article Number: 344367; Component Kind: Standard; ComponentLink1Description: Vendor WebSite; ComponentLink1URL: http://www.vishay.com; ComponentLink2Description: Datasheet; ComponentLink2URL: http://webnetprod.muc.infineon.com/PCBPortal_Prod/WorkFlows/AltiumComponent/StandardDocumentation?Versionid=344368; Legacy PartNumber: RES01800896; PackageReference: 0603; Part Type: Resistor; PLMStatus: Active; ROHS Compliant: Y; Second Source allowed: Yes; Supplier 1: Farnell; Supplier 2: DigiKey; Supplier 3: Mouser; Technology: Thick Film; Temperature max: 155; Temperature min: -55; Temperature Range: Military; Tolerance Pos: 1%; Type: Standard; Library Name: AURIX_Lite_Kit_V2.0.SCHLIB
R32 = 440112: Surface Mount Single Turn Trimmer, Model 23A - J Hook; Manufacturer: TT Electronics; Manufacturer Component Status: Active; Manufacturer Order Number: 23AR10KLFTR; Multiple Parts: No; Alternate View: Yes; Legacy DeviceID: RES01806167; Symbol Type: Resistor; Single; Variable; 3 Pins; Value: 23AR10KLFTR; Version Number: V01; Voltage DC (max): na; Application: Standard; Article Number: 440112; Component Kind: Standard; ComponentLink1Description: Vendor WebSite; ComponentLink1URL: http://www.ttelectronicsresistors.com/; ComponentLink2Description: Datasheet; ComponentLink2URL: http://webnetprod.muc.infineon.com/pcbportal_prod/WorkFlows/AltiumComponent/StandardDocumentation?Versionid=440113; Legacy PartNumber: RES01806167; Package Description: Trimmer, 5mm L X 4.5mm W X 3.1mm H Body; PackageReference: SMT; Part Type: Passive Device; PLMStatus: Active; RoHS Compliant: Y; Second Source allowed: Yes; Style: Single; Supplier 1: Farnell; Supplier 2: DigiKey; Supplier 3: Mouser; Supplier Part Number 1: 1520626RL; Supplier Part Number 2: 987-1008-2-ND; Supplier Part Number 3: 858-23AR10KLFTR; Temperature max: 125; Temperature min: -55; Temperature Range: Military; Type: Variable; Library Name: AURIX_Lite_Kit_V2.0.SCHLIB
R39-R43 = 343882: Standard Thick Film Chip Resistor; Manufacturer: Vishay; Manufacturer Order Number: CRCW06030000Z0; Multiple Parts: No; Alternate View: Yes; Automotive Qualified: AEC Q200; Combined Parameters: TCR:-;Tol.:-0R/+0R;Vdc:75V;Idc:2A;P:-; Legacy DeviceID: RES01800800; PLMStatus: Active; QuickPick: 0R / 0R / 0603; Style: Single; Symbol Type: Resistor; Single; Standard; 2 Pins; Tolerance Neg: 0R; Value: 0R; Version Number: V01; Voltage DC (max): 75V; Article Number: 343882; Component Kind: Standard; ComponentLink1Description: Vendor WebSite; ComponentLink1URL: http://www.vishay.com; ComponentLink2Description: Datasheet; ComponentLink2URL: http://webnetprod.muc.infineon.com/PCBPortal_Prod/WorkFlows/AltiumComponent/StandardDocumentation?Versionid=343883; Legacy PartNumber: RES01800800; PackageReference: 0603; Part Type: Resistor; ROHS Compliant: Y; Second Source allowed: Yes; Supplier 1: Farnell; Supplier 2: DigiKey; Supplier 3: Mouser; Supplier Part Number 2: 541-0.0GCT-ND; Technology: Thick Film; Temperature max: 155; Temperature min: -55; Temperature Range: Military; Tolerance Pos: 0R; Type: Standard; Library Name: AURIX_Lite_Kit_V2.0.SCHLIB
S2G1 S2G2 = Shield2Go-S2G-1P: 17 Pin Socket for Shield2Go Boards; Manufacturer: Infineon Technologies; Manufacturer Component Status: Active; AutomotiveQualified: no; ComponentName: S2G-1P; ComponentStatus: Released; ComponentHeight: 0mm; ComponentLink1Description: Vendor WebSite; ComponentLink1URL: http://www.infineon.com; ComponentLink2Description: Datasheet; Library Name: AURIX_Lite_Kit_V2.0.SCHLIB
U6 = 420527_NEW: 32-Bit Single-Chip Microcontroller with Hardware Security Mode; Legacy PartNumber: IFX01400135_NEW; Manufacturer: Infineon Technologies; Manufacturer Component Status: Active; Manufacturer Order Number: SAK-TC375TP-96F300W; Automotive Qualified: No; Combined Parameters: App.:-;-;Vdc min/max:-;Fmax:-;RAM:-;ROM:-;Flash:-; Legacy DeviceID: IFX_SAK-TC375TP-96F300W; Second Source allowed: Yes; Symbol type: TC375 32-Bit Single-Chip Microcontroller; Value: IFX_SAK-TC375TP-96F300W; Version Number: V01; Voltage DC (max): na; Article Number: 420527_NEW; Component Kind: Standard; ComponentLink1Description: Vendor WebSite; ComponentLink1URL: https://www.infineon.com/; ComponentLink2Description: Datasheet; ComponentLink2URL: http://webnetprod.muc.infineon.com/pcbportal_prod/WorkFlows/AltiumComponent/StandardDocumentation?Versionid=420528; Package Description: QFP,0.50mm pitch,square;176 pin,24.00mm L X 24.00mm W X 1.60mm H body (w/thermal tab); PackageReference: PG-LQFP-176; Part Type: Microcontroller; PLMStatus: Active; RoHS Compliant: Y; Supplier 1: Farnell; Supplier 2: DigiKey; Supplier 3: Mouser; Temperature Range: Automotive; Library Name: AURIX_Lite_Kit_V2.0.SCHLIB
X1 X2 = 594515: High temperature thermoplastic material, High retention force onto PCB, Bergstik Header; Manufacturer: Amphenol; Automotive Qualified: No; Combined Parameters: Board-to-Board Header (male);20x2;Mounting:THT;Orient:0Â° (vertical);Shielded:No; Legacy DeviceID: AMP_68691-440HLF; PLMStatus: Active; QuickPick: 0Â° (vertical) / Board-to-Board; Second Source allowed: Yes; Style: Strip; Version Number: V01; Voltage DC (max): na; Article Number: 594515; Component Kind: Standard; ComponentLink1Description: Vendor WebSite; ComponentLink1URL: http://www.amphenolcanada.com; ComponentLink2Description: Datasheet; ComponentLink2URL: http://webnetprod.muc.infineon.com/PCBPortal_Prod/WorkFlows/AltiumComponent/StandardDocumentation?Versionid=594516; Manufacturer Order Number: 68691-440HLF; Package Description: Header, THT, 2.54 mm Pitch, 40 pin, 4.83 mm L X 50.80 mm W X 10.95 mm H body; PackageReference: THT; Part Type: Connector; ROHS Compliant: Y; Supplier 1: Farnell; Supplier 2: DigiKey; Supplier 3: Mouser; Supplier Part Number 1: 3272975; Supplier Part Number 2: 609-6379-ND; Supplier Part Number 3: 649-68691-440HLF; Temperature max: 130; Temperature min: -65; Temperature Range: Military; Type: Board-to-Board; Value: 68691-440HLF; Library Name: AURIX_Lite_Kit_V2.0.SCHLIB
X3 = 382390_NEW: 2.1mm and 2.5mm Right Angle PCB Mount DC Power Socket 5A,12V dc, Nickel Plated; Legacy PartNumber: CON01500526; Manufacturer: Cliff; Manufacturer Order Number: FC681465P; Article Number: 382390; Automotive Qualified: No; Combined Parameters: Board-to-Cable Socket (female);-x-;Mounting:THT;Orient:90Â° (right angle);Shielded:No; Legacy DeviceID: CL-FC681465P; PLMStatus: Active; QuickPick: 90Â° (right angle) / Board-to-Cable; Second Source allowed: Yes; Symbol Type: Power Connector, Power Pins 1, 3, Normaly Closed Contact (NCC) 3, 2, 3 Pins; Value: FC681465P; Version Number: V01; Voltage DC (max): na; Component Kind: Standard; ComponentLink1Description: Vendor WebSite; ComponentLink1URL: www.cliffuk.co.uk/; ComponentLink2Description: Datasheet; ComponentLink2URL: https://www.cliffuk.co.uk/products/dcconnectors/FC681465P.pdf; Package Description: DC Power Jack, 14.5mm L X 9mm W X 11mm H body; Part Type: Connector; ROHS Compliant: Y; Style: Power Socket; Supplier 1: Farnell; Supplier 2: TME; Supplier 3: Distrelec; Supplier Part Number 1: 1854512; Supplier Part Number 2: FCR681465P; Supplier Part Number 3: 142-05-872; Temperature Range: Military; Type: Board-to-Cable; Library Name: AURIX_Lite_Kit_V2.0.SCHLIB
X301 = 382363: Through-Hole 0.025'' SQ Post Socket, Vertical, 2.54mm Pitch, 6 Pins, Single Row; Manufacturer Order Number: PPTC061LFBN-RC; Value: PPTC061LFBN-RC; Article Number: 382363; Component Kind: Standard; Library Name: AURIX_Lite_Kit_V2.0.SCHLIB
X302 X304 = 382156: .100” (2.54 mm) Contact Centers, Female Headers, Straight; Manufacturer Order Number: PPTC081LFBN-RC; Value: PPTC081LFBN-RC; Article Number: 382156; Component Kind: Standard; Library Name: AURIX_Lite_Kit_V2.0.SCHLIB
X303 = 381812: Through-Hole 0.025'' SQ Post Socket, Vertical, 2.54mm Pitch, 10 Pins, Single Row; Article Number: 381812; Component Kind: Standard; Manufacturer Order Number: PPTC101LFBN-RC; Value: PPTC101LFBN-RC; Library Name: AURIX_Lite_Kit_V2.0.SCHLIB
Y2 = 408282: SMD Crystal Unit for Automotive Application, 20.000MHz, 8pF; Legacy PartNumber: IC02600500; Manufacturer: Nihon Dempa Kogyo; Manufacturer Component Status: Active; Manufacturer Order Number: NX8045GB-20.000M-STD-CSJ-1; Automotive Qualified: AEC Q200; Combined Parameters: Standard Standard Crystal;Tol.:-; ComponentStatus: Released; Legacy DeviceID: NDK_NX8045GB-20.000M-STD-CSJ-1; QuickPick: 20000000 / Crystal; Second Source allowed: Yes; Style: Standard; Symbol type: Standard Crystal Symbol, 2 Pins; Version Number: V01; Voltage DC (max): na; Application: Standard; Article Number: 408282; Component Kind: Standard; ComponentLink1Description: Vendor WebSite; ComponentLink1URL: http://www.ndk.com/en/; ComponentLink2Description: Datasheet; ComponentLink2URL: http://webnetprod.muc.infineon.com/PCBPortal_Prod/WorkFlows/AltiumComponent/StandardDocumentation?Versionid=408283; Package Description: Crystal; 8.00mm W X 4.50mm L X 2.00mm H body; PackageReference: SMD 8.0 x 4.5 x 2.0 mm; Part Type: Clock; PLMStatus: Active; ROHS Compliant: Y; Supplier 1: Farnell; Supplier 2: DigiKey; Supplier 3: Mouser; Temperature max: 150; Temperature min: -40; Temperature Range: Automotive; Type: Crystal; Value: 20MHz; Library Name: AURIX_Lite_Kit_V2.0.SCHLIB
